# Altium schematic sheet Module.SchDoc(Module)
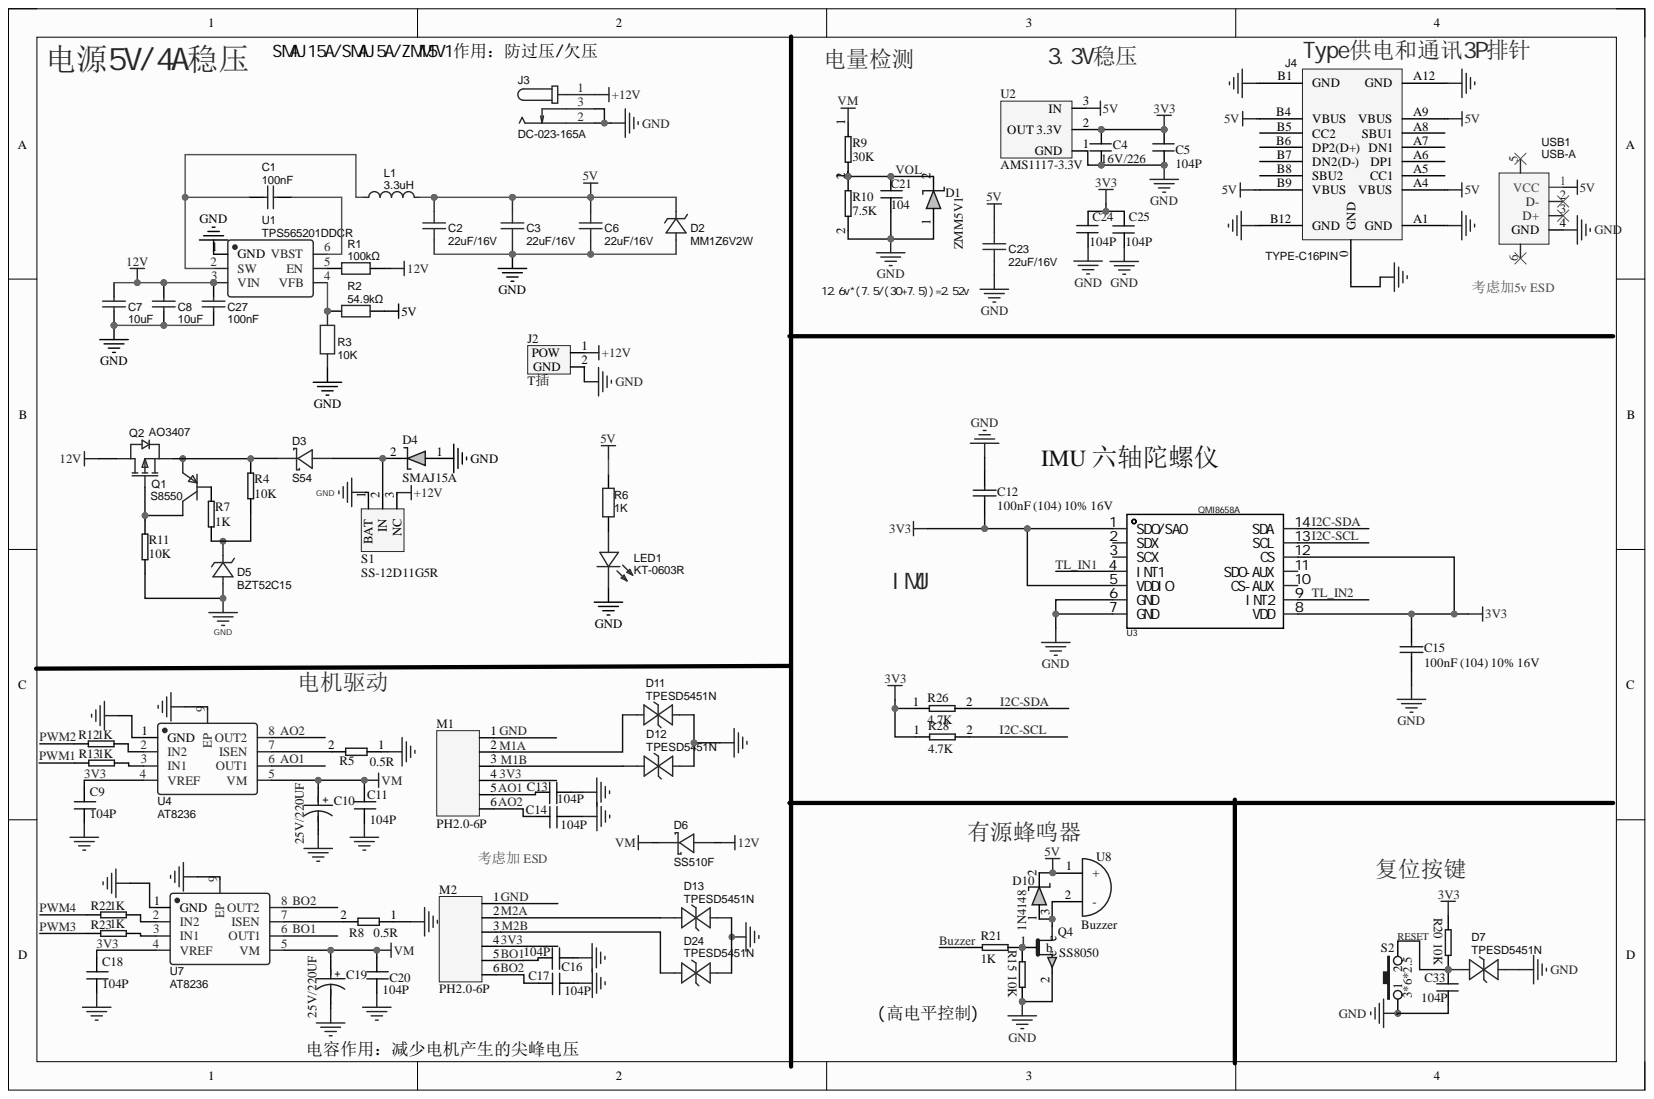
[diagram p0: Module.SchDoc]

## Parts
C1  100nF  [C0603]
C2  22uF/16V  [C0603]
C3  22uF/16V  [C0603]
C4  16V/226  [C0603]
C5  104P  [C0603]
C6  22uF/16V  [C0603]
C7  10uF  [C0603]
C8  10uF  [C0603]
C9  104P  [C0603]
C10  25V/220UF  [C6X12卧式]
C11  104P  [C0603]
C12  100nF (104) 10% 16V  [0402_C]
C13  104P  [C0603]
C14  104P  [C0603]
C15  100nF (104) 10% 16V  [0402_C]
C16  104P  [C0603]
C17  104P  [C0603]
C18  104P  [C0603]
C19  25V/220UF  [C6X12卧式]
C20  104P  [C0603]
C21  104  [C0603]
C23  22uF/16V  [C0603]
C24  104P  [C0603]
C25  104P  [C0603]
C27  100nF  [C0603]
C33  104P  [C0603]
D1  ZMM5V1  [ZMM5V1LL-34_L3.5-W1.5-RD]
D2  MM1Z6V2W  [MM1Z6V2SOD-123F_L2.7-W1.6-LS3.8-RD]
D3  S54  [S54SMAF_L3.5-W2.6-LS4.7-RD]
D4  SMAJ15A  [SMA]
D5  BZT52C15  [BAT52C15SOD-123_L2.7-W1.6-LS3.7-RD]
D6  SS510F  [S54SMAF_L3.5-W2.6-LS4.7-RD]
D7  TPESD5451N  [DFN1006-2L-BI]
D10  1N4148  [Dio1206]
D11  TPESD5451N  [DFN1006-2L-BI]
D12  TPESD5451N  [DFN1006-2L-BI]
D13  TPESD5451N  [DFN1006-2L-BI]
D24  TPESD5451N  [DFN1006-2L-BI]
J2  T插  [T插]  (value not drawn)
J3  DC-023-165A  [DC-IN-TH_DC-023-165A]
J4  TYPE-C16PIN  [USB-C-SMD_TYPE-C16PINN]
L1  3.3uH  [3.3UHIND-SMD_L5.4-W5.2_RS0530]
LED1  KT-0603R  [LED0603-RD]
M1  PH2.0-6P  [PH  6P卧式]
M2  PH2.0-6P  [PH  6P卧式]
Q1  S8550  [SOT-23-3_L2.9-W1.3-P1.90-LS2.4-BR]
Q2  AO3407  [SOT-23-3_L2.9-W1.3-P1.90-LS2.4-BR]
Q4  SS8050  [SOT-23-3]
R1  100kΩ  [R0603]
R2  54.9kΩ  [R0603]
R3  10K  [R0603]
R4  10K  [R0603]
R5  0.5R  [R2512]
R6  1K  [R0603]
R7  1K  [R0603]
R8  0.5R  [R2512]
R9  30K  [R0603]
R10  7.5K  [R0603]
R11  10K  [R0603]
R12  1K  [R0603]  (value not drawn)
R13  1K  [R0603]  (value not drawn)
R15  10K  [R0603]
R20  10K  [R0603]
R21  1K  [R0603]
R22  1K  [R0603]  (value not drawn)
R23  1K  [R0603]  (value not drawn)
R26  4.7K  [R0603]
R28  4.7K  [R0603]
S1  SS-12D11G5R  [SW-TH_SS-12D11G5R]
S2  3*6*2.5  [TSW DIP-3*6*5_V]
U1  TPS565201DDCR  [SOT-23-6_L2.9-W1.6-P0.95-LS2.8-BR]
U2  AMS1117-3.3V  [SOT-223]
U3  QMI8658A  [LGA-14_L3.0-W2.5-P0.50-TL]
U4  AT8236  [ESOP-8]
U7  AT8236  [ESOP-8]
U8  Buzzer  [Buzzer 有源3V  0905]
USB1  USB-A  [USB-A-TH_JTJ-USB-AF-03]

## Nets
5V: C2.2 C3.2 C6.2 C23.2 D2.1 D10.2 J4.A4(VBUS) J4.A9(VBUS) J4.B4(VBUS) J4.B9(VBUS) L1.2 R2.2 R6.2 U2.3 U8.1 USB1.1(VCC)
12V: C7.2 C8.2 C27.2 D6.2 J2.1 J3.1 Q2.3 R1.1 S1.3 U1.3(VIN)
VM: C10.1 C11.2 C19.1 C20.2 D6.1 R9.1 U4.5(VM) U7.5(VM)
3V3: C4.1 C5.1 C9.2 C12.1 C15.2 C18.2 C24.1 C25.1 M1.4 M2.4 R20.1 R26.1 R28.1 U2.2 U3.1(SDO/SA0) U3.5(VDDIO) U3.8(VDD) U3.12(CS) U4.4(VREF) U7.4(VREF)
AO1: C13.1 M1.5 U4.6(OUT1)
AO2: C14.1 M1.6 U4.8(OUT2)
BO1: C16.1 M2.5 U7.6(OUT1)
BO2: C17.1 M2.6 U7.8(OUT2)
Buzzer: R21.2
GND: C2.1 C3.1 C4.2 C5.2 C6.1 C7.1 C8.1 C9.1 C10.2 C11.1 C12.2 C13.2 C14.2 C15.1 C16.2 C17.2 C18.1 C19.2 C20.1 C21.1 C23.1 C24.2 C25.2 C27.1 C33.2 D1.1 D2.2 D4.1 D5.2 D7.2 D11.2 D12.2 D13.2 D24.2 J2.2 J3.2 J3.3 J4.0(GND) J4.A1(GND) J4.A12(GND) J4.B1(GND) J4.B12(GND) LED1.2 M1.1 M2.1 Q4.2 R3.2 R5.1 R8.1 R10.2 R11.1 R15.2 S1.1 S2.1 U1.1(GND) U2.1 U3.6(GND) U3.7(GND) U4.1(GND) U4.9(EP) U7.1(GND) U7.9(EP) USB1.4(GND)
I2C-SCL: R28.2 U3.13(SCL)
I2C-SDA: R26.2 U3.14(SDA)
M1A: D11.1 M1.2
M1B: D12.1 M1.3
M2A: D13.1 M2.2
M2B: D24.1 M2.3
PWM1: R13.1
PWM2: R12.1
PWM3: R23.1
PWM4: R22.1
RESET: C33.1 D7.1 R20.2 S2.2
TL_IN1: U3.4(INT1)
TL_IN2: U3.9(INT2)
VOL: C21.2 D1.2 R9.2 R10.1
NetC1_1: C1.1 U1.6(VBST)
NetC1_2: C1.2 L1.1 U1.2(SW)
NetD3_1: D3.1 Q1.2 Q2.2 R4.2
NetD3_2: D3.2 D4.2 S1.2
NetD5_1: D5.1 R4.1 R7.1
NetD10_1: D10.1 Q4.3 U8.2
NetLED1_1: LED1.1 R6.1
NetQ1_1: Q1.1 R7.2
NetQ1_3: Q1.3 Q2.1 R11.2
NetQ4_1: Q4.1 R15.1 R21.1
NetR1_2: R1.2 U1.5(EN)
NetR2_1: R2.1 R3.1 U1.4(VFB)
NetR5_2: R5.2 U4.7(ISEN)
NetR8_2: R8.2 U7.7(ISEN)
NetR12_2: R12.2 U4.2(IN2)
NetR13_2: R13.2 U4.3(IN1)
NetR22_2: R22.2 U7.2(IN2)
NetR23_2: R23.2 U7.3(IN1)
12.6v*(7.5/(30+7.5))=2.52v:

Not connected: J4 A5(CC1) A6(DP1) A7(DN1) A8(SBU1) B5(CC2) B6(DP2(D+)) B7(DN2(D-)) B8(SBU2); U3 2(SDX) 3(SCX) 10(CS-AUX) 11(SDO-AUX); USB1 2(D-) 3(D+) 5 6

## Library data of the parts
C1 C27 = 100nF: Manufacturer: SAMSUNG; Manufacturer Part: CL10A106MO8NQNC; nameAlias: Capacitance; Component Kind: Standard; JLCPCB Part Class: Extended Part; spicePre: C; spiceSymbolName: CL10A106MO8NQNC; Supplier: LCSC; Supplier Part: C92487
C2 C3 C6 C23 = 22uF/16V: Manufacturer: HRE(芯声); Manufacturer Part: CGA0603X5R226M160JT; Contributor: lcsc; nameAlias: Value; Component Kind: Standard; JLCPCB Part Class: Extended Part; spicePre: C; spiceSymbolName: CGA0603X5R226M160JT; Supplier: LCSC; Supplier Part: C6119868
C4 C5 C9 C11 C13 C14 C16-C18 C20 C21 C24 C25 C33 = Cap: Component Kind: Standard; Library Name: CZ 3D.IntLib
C7 C8 = 10uF: Manufacturer: SAMSUNG; Manufacturer Part: CL10A106MO8NQNC; nameAlias: Capacitance; Component Kind: Standard; JLCPCB Part Class: Extended Part; spicePre: C; spiceSymbolName: CL10A106MO8NQNC; Supplier: LCSC; Supplier Part: C92487
C10 C19 = Cap 贴片电解: Component Kind: Standard; Library Name: CZ 3D.IntLib
C12 C15 = 0402 100nF (104) 10% 16V: 贴片电容; Component Kind: Standard; ComponentLink1Description: 供应商链接; ComponentLink1URL: http://www.szlcsc.com/product/details_1877.html; Library Name: Miscellaneous Devices LC.IntLib; Package: 0402; Supplier: LC; SuppliersPartNumber: C1525
D1 D4 D10 = Diode: Component Kind: Standard; Library Name: CZ 3D.IntLib
D2 = MM1Z6V2W: Manufacturer: JSMSEMI(杰盛微); Contributor: lcsc; Component Kind: Standard; JLCPCB Part Class: Extended Part; Manufacturer Part: MM1Z6V2W; spicePre: U; spiceSymbolName: MM1Z6V2W; Supplier: LCSC; Supplier Part: C2856856
D3 D6 = SS510F: Manufacturer: Jingdao; Contributor: LCSC; SMT Type: Extend; Component Kind: Standard; LCSC Assembly: Yes; Manufacturer Part: SS510F; spicePre: D; Supplier: LCSC; Supplier Part: C123945
D5 = BZT52C5V6S-TP: Manufacturer: MCC(美微科); Contributor: LCSC; Component Kind: Standard; JLCPCB Part Class: Extended Part; Manufacturer Part: BZT52C5V6S-TP; spicePre: D; spiceSymbolName: BZT52C5V6S-TP; Supplier: LCSC; Supplier Part: C779167
D7 D11-D13 D24 = TPESD5451N: Manufacturer: TECH PUBLIC(台舟); Contributor: LCSC; Component Kind: Standard; JLCPCB Part Class: Extended Part; link: https://so.szlcsc.com/global.html?k=C970008&ref=editor; Manufacturer Part: TPESD5451N; spicePre: D; spiceSymbolName: TPESD5451N; Supplier: LCSC; Supplier Part: C970008
J3 = DC-023-165A: BOM_Manufacturer: 韩国韩荣; Contributor: LCSC; BOM_JLCPCB Part Class: Extended Part; BOM_Manufacturer Part: DC-023-165A; BOM_Supplier: LCSC; BOM_Supplier Part: C707291; Component Kind: Standard; Library Name: CZ 3D.SchLib; spicePre: D; spiceSymbolName: DC-023-165A
J4 = TYPE-C16PIN: BOM_Manufacturer: SHOU HAN; Contributor: LCSC; BOM_Manufacturer Part: TYPE-C16PIN; BOM_Supplier: LCSC; BOM_Supplier Part: C393939; Component Kind: Standard; Library Name: CZ 3D.SchLib; spicePre: U; spiceSymbolName: TYPE-C16PIN
L1 = 3.3uH: Manufacturer: Ruishen(瑞申电子); Manufacturer Part: RS0530-3R3MS; Contributor: lcsc; nameAlias: Value; Component Kind: Standard; JLCPCB Part Class: Extended Part; spicePre: L; spiceSymbolName: RS0530-3R3MS; Supplier: LCSC; Supplier Part: C32712971
LED1 = KT-0603R: Manufacturer: KENTO; SMT Type: Basic; Component Kind: Standard; image: //image.lceda.cn/szlcsc/C2286.jpg; LCSC Assembly: Yes; Manufacturer Part: KT-0603R; spicePre: L; Supplier: LCSC; Supplier Part: C2286
M1 M2 = PH-6P: Component Kind: Standard; Library Name: CZ 3D.SchLib
Q1 = S8550_C2840973: Manufacturer: PSI(宝力芯); Contributor: LCSC; Component Kind: Standard; JLCPCB Part Class: Extended Part; Manufacturer Part: S8550; spicePre: Q; spiceSymbolName: S8550_C2840973; Supplier: LCSC; Supplier Part: C2840973
Q2 = AO3401: Manufacturer: JSMSEMI(杰盛微); Contributor: lcsc; Component Kind: Standard; JLCPCB Part Class: Extended Part; Manufacturer Part: AO3401; spicePre: U; spiceSymbolName: AO3401_C5296722; Supplier: LCSC; Supplier Part: C5296722
Q4 = 8050: Component Kind: Standard; Library Name: CZ 3D.IntLib
R1 = 100kΩ: Manufacturer: UNI-ROYAL(厚声); Manufacturer Part: 0603WAF1003T5E; nameAlias: Value; Component Kind: Standard; JLCPCB Part Class: Basic Part; spicePre: R; spiceSymbolName: 0603WAF1003T5E; Supplier: LCSC; Supplier Part: C25803
R2 = 54.9kΩ: Manufacturer: UNI-ROYAL(厚声); Manufacturer Part: 0603WAF5492T5E; nameAlias: Value; Component Kind: Standard; JLCPCB Part Class: Extended Part; spicePre: R; spiceSymbolName: 0603WAF5492T5E; Supplier: LCSC; Supplier Part: C23077
R3 = 10K: Manufacturer: YAGEO; Manufacturer Part: RC0603FR-0710KL; Contributor: TheJun; Component Kind: Standard; spicePre: R; spiceSymbolName: R_0603_EU; Supplier: LCSC; Supplier Part: C98220
R4 R7 R9-R13 R15 R20-R23 R26 R28 = Res: Component Kind: Standard; Library Name: CZ 3D.IntLib
R5 R8 = HJ R: Component Kind: Standard; Library Name: tracing car.SchLib
R6 = 1K: Manufacturer: UniOhm; Manufacturer Part: 0603WAF1001T5E; nameAlias: Resistance (Ohms); Component Kind: Standard; LCSC Assembly: Yes; spicePre: R; spiceSymbolName: 0603WAF1001T5E; Supplier: LCSC; Supplier Part: C21190
S1 = SW Toggle: Component Kind: Standard; Library Name: CZ 3D.IntLib
S2 = KEY 2P: Component Kind: Standard; Library Name: CZ 3D.IntLib
U1 = TPS565201DDCR: Manufacturer: TI(德州仪器); Contributor: LCSC; Component Kind: Standard; JLCPCB Part Class: Extended Part; Manufacturer Part: TPS565201DDCR; spicePre: U; spiceSymbolName: TPS565201DDCR; Supplier: LCSC; Supplier Part: C327676
U2 = AMS1117: Component Kind: Standard; Library Name: CZ 3D.IntLib
U3 = QMI8658A_C3021082: 6轴陀螺仪; Manufacturer: QST(上海矽睿); Add into BOM: yes; Component Kind: Standard; Convert to PCB: yes; Datasheet: https://atta.szlcsc.com/upload/public/pdf/source/20230804/748E61E1BAEF8C31413DC641004C80DF.pdf; Device: QMI8658A_C3021082; JLCPCB Part Class: Extended Part; LCSC Part Name: 六轴陀螺仪; Library Name: Schlib2.SchLib; Manufacturer Part: QMI8658A; PCB3D: LGA-14_L2.5-W3.0-H0.9-P0.50; Supplier: LCSC; Supplier Footprint: LGA-14; Supplier Part: C3021082; Symbol: QMI8658A_C3021082
U4 U7 = AT8236: BOM_Manufacturer: null; Contributor: LCSC; BOM_JLCPCB Part Class: Extended Part; BOM_Manufacturer Part: AT8236; BOM_Supplier: LCSC; BOM_Supplier Part: C2827823; Component Kind: Standard; Library Name: CZ 3D.SchLib; spicePre: U; spiceSymbolName: AT8236
USB1 = USB-A: BOM_Manufacturer: ValuePro; BOM_Manufacturer Part: AF Bend foot Have side Inside the bomb iron PBT White plastic 90degree; BOM_JLCPCB Part Class: Extended Part; BOM_Supplier: LCSC; BOM_Supplier Part: C39431; Component Kind: Standard; spicePre: U; spiceSymbolName: AF Bend foot Have side Inside the bomb iron PBT White plastic 90degree; Library Name: ZD.SchLib
J2 = T插: Component Kind: Standard; Library Name: CZ 3D.IntLib
U8 = Buzzer: Component Kind: Standard; Library Name: CZ 3D.IntLib
